# Altium schematic sheet FPGA_B35.SchDoc(FPGA_B35)
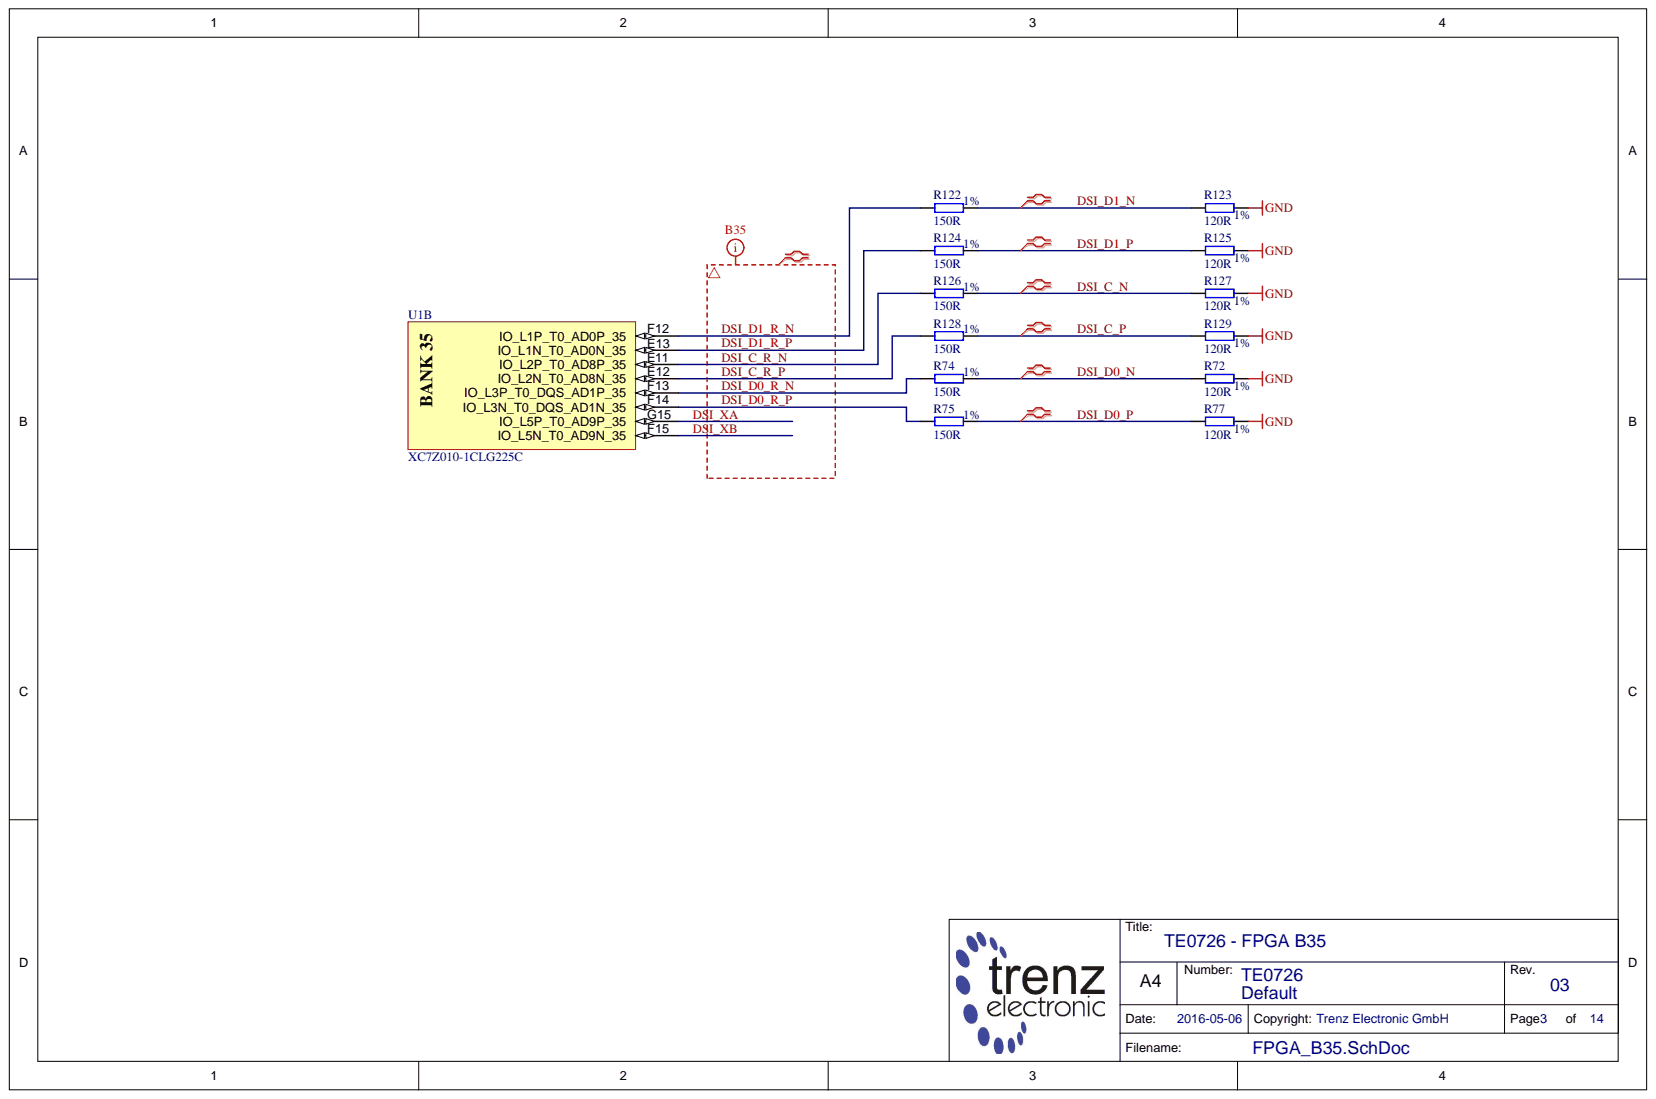
[diagram p0: FPGA_B35.SchDoc]

## Parts
R72  120R  Tolerance: 1%  [RESC1005X40N]
R74  150R  Tolerance: 1%  [RESC1005X40N]
R75  150R  Tolerance: 1%  [RESC1005X40N]
R77  120R  Tolerance: 1%  [RESC1005X40N]
R122  150R  Tolerance: 1%  [RESC1005X40N]
R123  120R  Tolerance: 1%  [RESC1005X40N]
R124  150R  Tolerance: 1%  [RESC1005X40N]
R125  120R  Tolerance: 1%  [RESC1005X40N]
R126  150R  Tolerance: 1%  [RESC1005X40N]
R127  120R  Tolerance: 1%  [RESC1005X40N]
R128  150R  Tolerance: 1%  [RESC1005X40N]
R129  120R  Tolerance: 1%  [RESC1005X40N]
U1  XC7Z010-1CLG225C  [BGA225C80P15X15_1300X1300X150B40N]  (part B)

## Nets
GND: R72.2 R77.1 R123.2 R125.2 R127.2 R129.2
DSI_C_N: R126.2 R127.1
DSI_C_P: R128.2 R129.1
DSI_C_R_N: R126.1 U1.E11(IO_L2P_T0_AD8P_35)
DSI_C_R_P: R128.1 U1.E12(IO_L2N_T0_AD8N_35)
DSI_D0_N: R72.1 R74.2
DSI_D0_P: R75.2 R77.2
DSI_D0_R_N: R74.1 U1.F13(IO_L3P_T0_DQS_AD1P_35)
DSI_D0_R_P: R75.1 U1.F14(IO_L3N_T0_DQS_AD1N_35)
DSI_D1_N: R122.2 R123.1
DSI_D1_P: R124.2 R125.1
DSI_D1_R_N: R122.1 U1.F12(IO_L1P_T0_AD0P_35)
DSI_D1_R_P: R124.1 U1.E13(IO_L1N_T0_AD0N_35)
DSI_XA: U1.G15(IO_L5P_T0_AD9P_35)
DSI_XB: U1.F15(IO_L5N_T0_AD9N_35)
N00146:
N00147:

## Library data of the parts
R72 R77 R123 R125 R127 R129 = 22765: WID SMD 120R 1% 0,063W; Database Table Name: R_1005_0402; Mixed: SMT; Preferred: Yes; Value: 120; AN: 22765; Case: 1005 (0402); Component Kind: Standard; Library Name: TE.DbLib; Technology: TK100
R74 R75 R122 R124 R126 R128 = 22766: WID SMD 150R 1% 0,063W; Database Table Name: R_1005_0402; Mixed: SMT; Preferred: Yes; Value: 150; AN: 22766; Case: 1005 (0402); Component Kind: Standard; Library Name: TE.DbLib; Technology: TK100
U1 = 26308: Database Table Name: PROG_LOGIC; Mixed: SMT; Preferred: Yes; AN: 26308; Case: CLG225; Component Kind: Standard; Description: XC7Z010-1CLG225C; H-ART: XC7Z010-1CLG225C; Library Name: TE.DbLib; Trenz-Stock: Yes; HelpURL: B:\AD\adlib\datasheet\XC7\Zynq
